# Altium schematic sheet CAN TRAN.SchDoc
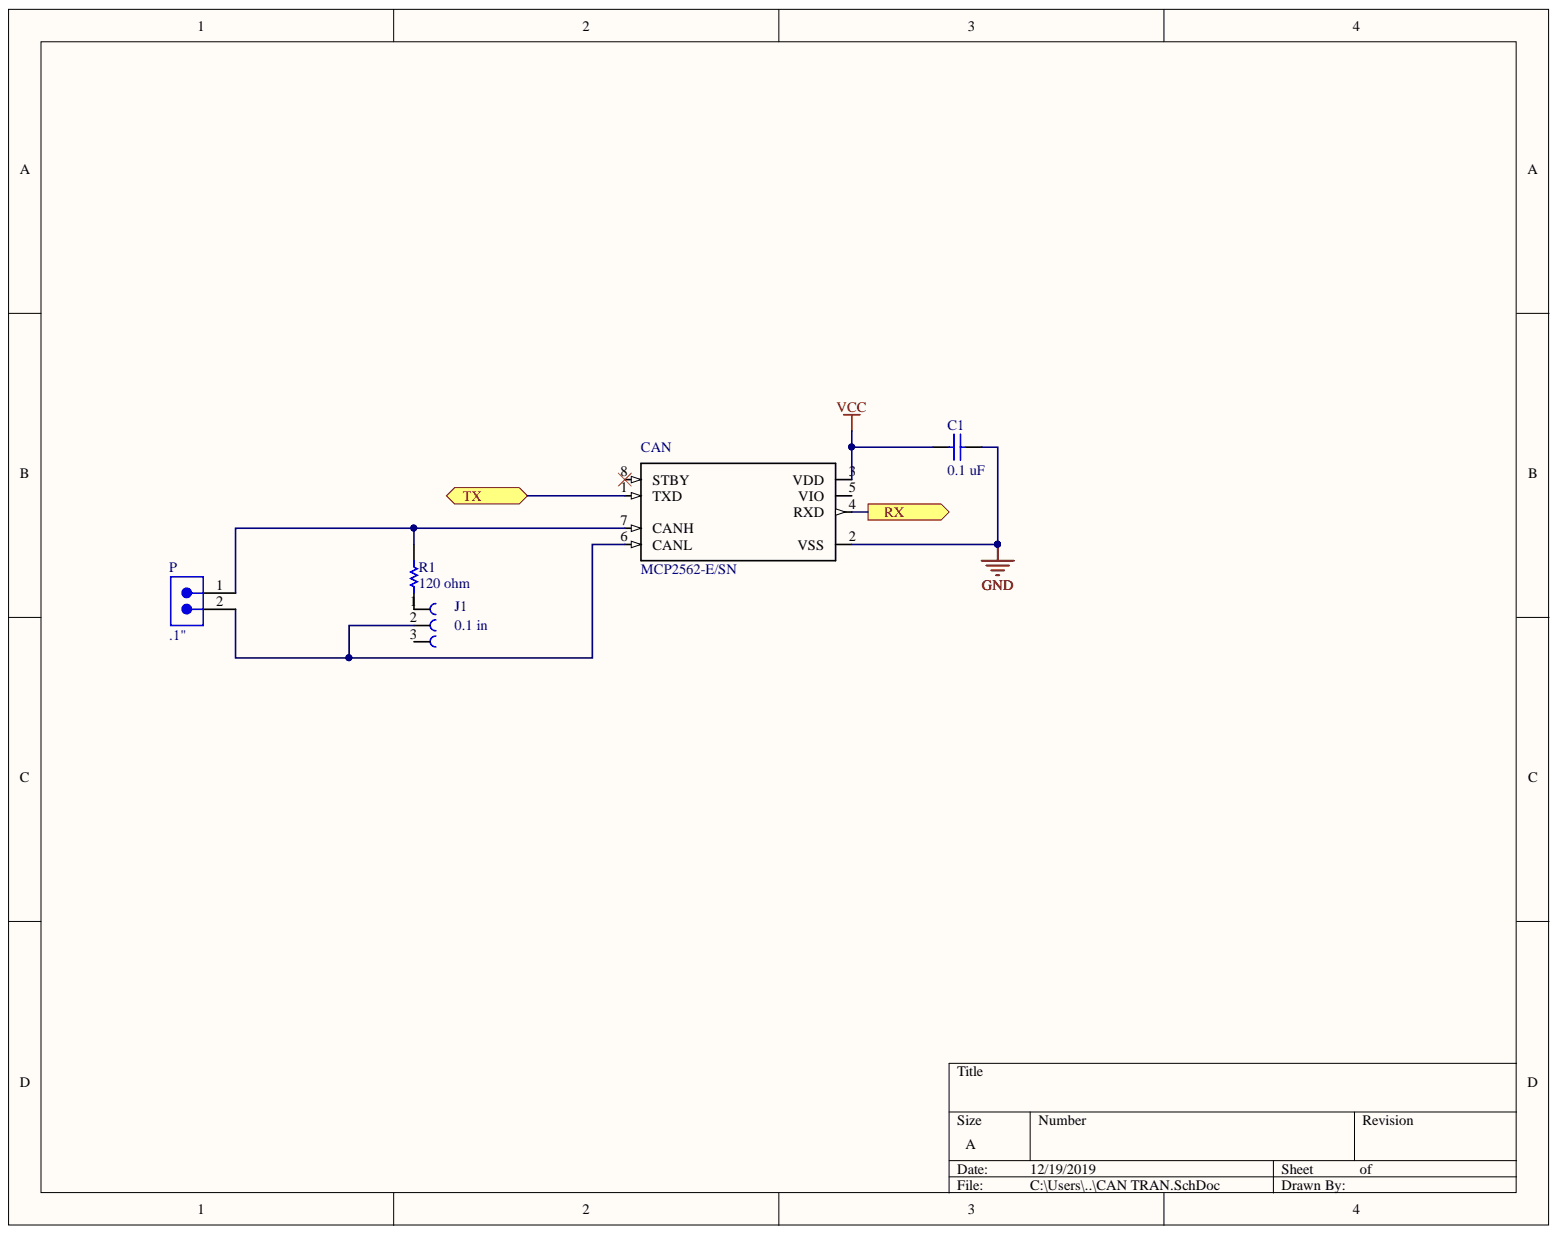
[diagram p0: CAN TRAN.SchDoc]

## Parts
C1  0.1 uF  [CAPC0805(2012)130_N]
CAN  MCP2562-E/SN  [SOIC127P600X175-8N]
J1  0.1 in  [61300311121]
P  .1"  [SMTC-MTLW-102-05-X-S-172]
R1  120 ohm  [RESC0805(2012)_N]

## Ports
RX, TX

## Nets
GND: C1.1 CAN.2(VSS)
VCC: C1.2 CAN.3(VDD)
RX: CAN.4(RXD) ; port RX
TX: CAN.1(TXD) ; port TX
NetCAN_6: CAN.6(CANL) J1.2 P.2
NetCAN_7: CAN.7(CANH) P.1 R1.2
NetJ1_1: J1.1 R1.1

Not connected: CAN 5(VIO) 8(STBY); J1 3

## Library data of the parts
C1 = CMP-1036-02603-1: CAP 390pF 200V ±5% 0805 (2012 Metric) Thickness 1.3mm SMD; Case-EIA: 0805; Case-Metric: 2012; Tolerance: Â±5%; Component Kind: Standard; Library Name: Altium Content Vault; Max Thickness: 1.3 mm; Rated Voltage: 200 V; Technology: SMT; Value: 390pF
CAN = MCP2562-E/SN: Microchip MCP2562-E/SN, CAN Transceiver 1MBps 1-channel IEC 61000-4-2, 8-Pin SOIC; Availability: Unavailable; Component Kind: Standard; ComponentLink1Description: datasheet; ComponentLink1URL: http://www.ti.com/lit/ds/symlink/tcan332.pdf; MF: Microchip; MP: MCP2562-E/SN; Package: SO-8 Microchip; Price: None; SnapEDA_Link: https://www.snapeda.com/parts/MCP2562-E/SN/Microchip/view-part/?ref=snap; Library Name: CAN Tranceiver (2).SchLib
J1 = 61300311121: Board-To-Board Connector, Vertical, WR-PHD Series, Through Hole, Header, 3, 2.54 mm; MF: Wurth Electronics; Availability: Unavailable; Component Kind: Standard; Library Name: 61300311121.IntLib; MP: 61300311121; Package: None; Price: None; SnapEDA_Link: https://www.snapeda.com/parts/61300311121/Wurth%20Electronics%20Inc./view-part/?ref=snap
P = CMP-1024-00065-1: Low Profile .025" SQ Post Header, Through-hole, Vertical, -55 to 105 degC, 2.54 mm Pitch, 2-Pin, Male, RoHS; Manufacturer: Samtec; PartNumber: MTLW-102-05-T-S-172; Pitch: 2.54 mm; Component Kind: Standard; ComponentLink1Description: Manufacturer URL; ComponentLink1URL: http://www.samtec.com; ComponentLink2Description: Datasheet; ComponentLink2URL: http://www.samtec.com/documents/webfiles/pdf/MTLW_TH.PDF; ComponentLink3Description: Package Specification; ComponentLink3URL: http://www.samtec.com/documents/webfiles/cpdf/MTLW-1XX-XX-XX-X-XXX-XX-XX-MKT1.pdf; DatasheetVersion: F-214; Library Name: Altium Content Vault; Mounting Technology: Through-hole; Orientation: Vertical; PackageDescription: Low Profile Terminal Assembly; PackageReference: MTLW-102-05-X-S-172; PackageVersion: T, 6/1991; RoHS: True; Temperature Range: -55 to 105 degC
R1 = CMP-1013-00418-1: 160R 0.125W 1% 0805 (2012 Metric)  SMD; Case-EIA: 0805; Case-Metric: 2012; Value: 160.00 Ohm; Component Kind: Standard; Library Name: Altium Content Vault; Power: 0.125 W; Technology: SMT; Tolerance: 1 %
